# Altium schematic sheet Dual DC Motor Driver Rev.1.0.SchDoc
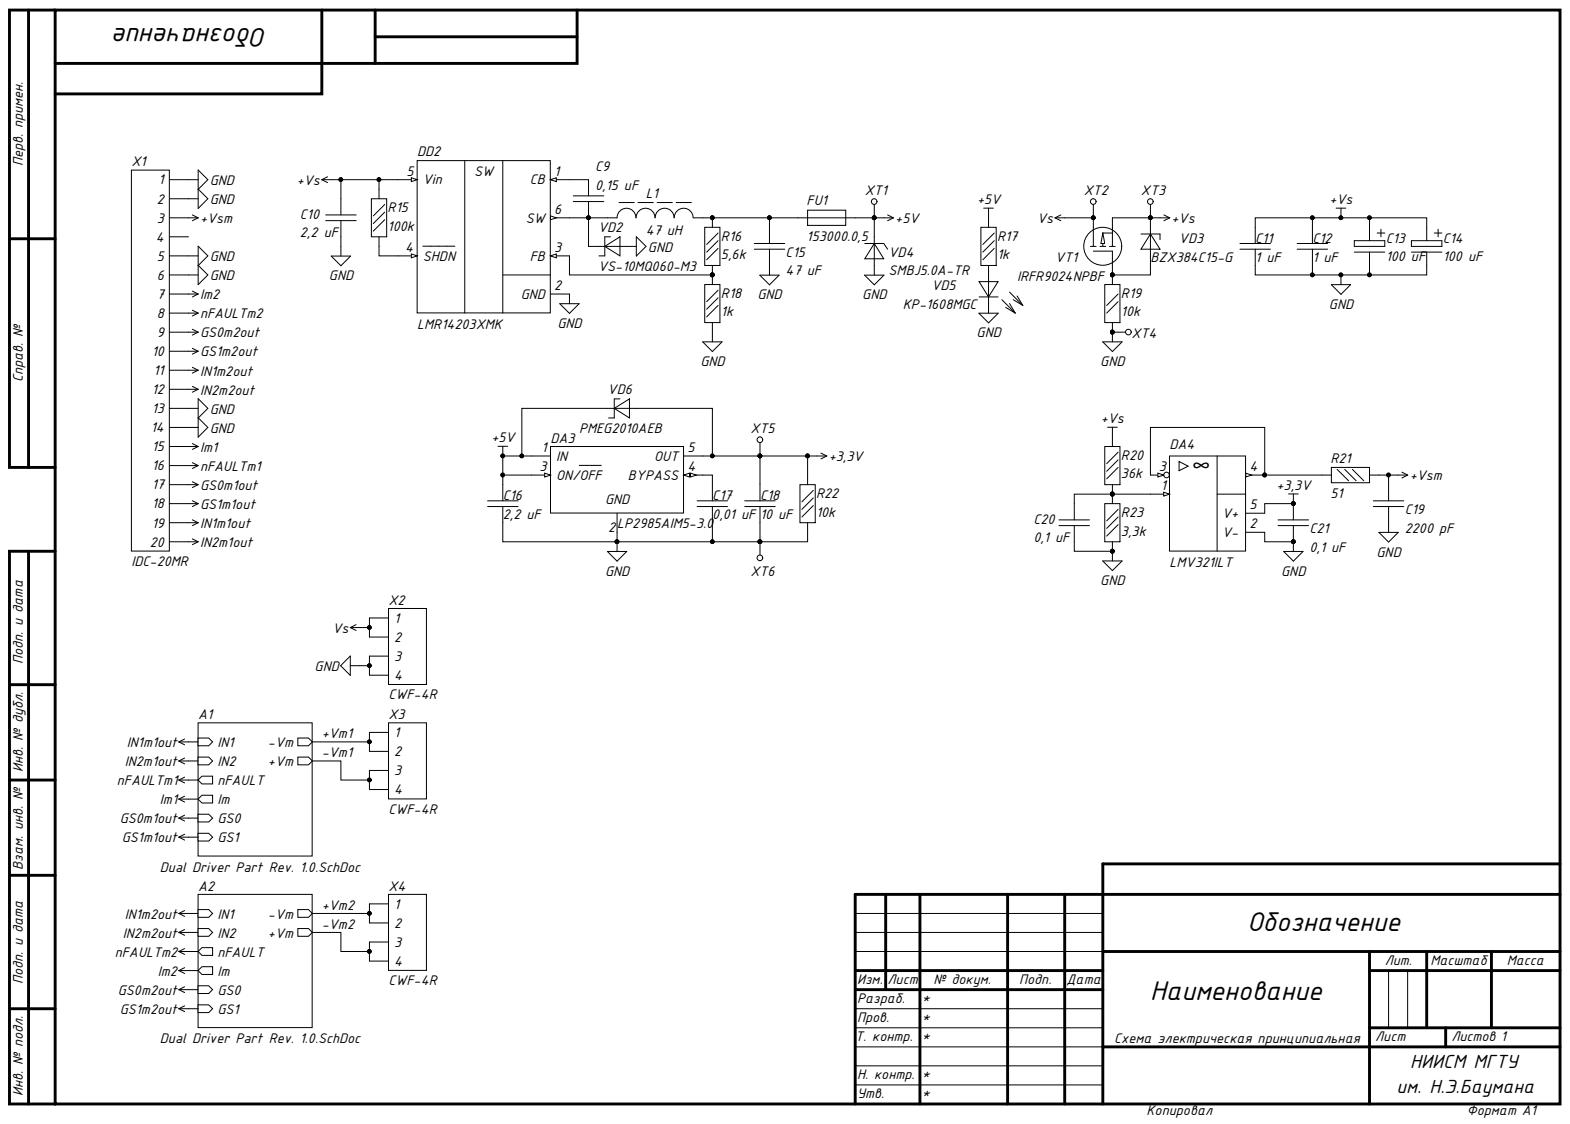
[diagram p0: Dual DC Motor Driver Rev.1.0.SchDoc]

## Parts
C9  Конденсатор  [CAPС_0603(1608)]  (value not drawn)
C10  Capacitance: 2,2 uF  [CAPС_1206(3216)]  (hidden comment: Конденсатор)
C11  Capacitance: 1 uF  [CAPС_1206(3216)]  (hidden comment: Конденсатор)
C12  Capacitance: 1 uF  [CAPС_1206(3216)]  (hidden comment: Конденсатор)
C13  EEUFC1J101  [D10L12.5d0.6F5.SLDPRT]  (value not drawn)
C14  Capacitance: 100 uF  [D10L12.5d0.6F5.SLDPRT]  (hidden comment: EEUFC1J101)
C15  Capacitance: 47 uF  [CAPС_1206(3216)]  (hidden comment: Конденсатор)
C16  Конденсатор  [CAPС_0603(1608)]  (value not drawn)
C17  Конденсатор  [CAPС_0603(1608)]  (value not drawn)
C18  Capacitance: 10 uF  [CAPС_0603(1608)]  (hidden comment: Конденсатор)
C19  Конденсатор точн.  [CAPС_0603(1608)]  (value not drawn)
C20  Capacitance: 0,1 uF  [CAPС_0603(1608)]  (hidden comment: Конденсатор)
C21  Capacitance: 0,1 uF  [CAPС_0603(1608)]  (hidden comment: Конденсатор)
DA3  LP2985AIM5-3.0  [SOT23-5]
DA4  LMV321ILT  [SOT23-5]
DD2  LMR14203XMK  [SOT23-6]
FU1  Предохранитель плавкий  [FUSC_0805(2012)]  (value not drawn)
L1  Inductance: 47 uH  [IND-6.5x6.5x4.6r0.8]  (hidden comment: SRR0604-470KL)
R15  Resistance: 100k  [RESC_0603(1608)]  (hidden comment: Резистор 0,05 Вт)
R16  Resistance: 5,6k  [RESC_0603(1608)]  (hidden comment: Резистор 0,05 Вт точн.)
R17  Resistance: 1k  [RESC_0603(1608)]  (hidden comment: Резистор 0,05 Вт)
R18  Resistance: 1k  [RESC_0603(1608)]  (hidden comment: Резистор 0,05 Вт точн.)
R19  Resistance: 10k  [RESC_0805(2012)]  (hidden comment: Резистор 0,125 Вт)
R20  Resistance: 36k  [RESC_0603(1608)]  (hidden comment: Резистор 0,05 Вт точн.)
R21  Резистор 0,05 Вт точн.  [RESC_0603(1608)]  (value not drawn)
R22  Resistance: 10k  [RESC_0603(1608)]  (hidden comment: Резистор 0,05 Вт)
R23  Resistance: 3,3k  [RESC_0603(1608)]  (hidden comment: Резистор 0,05 Вт точн.)
VD2  VS-10MQ060-M3  [DIODE_SMA]
VD3  BZX384C15-G  [SOD323]
VD4  SMBJ5.0A-TR  [DIODE_SMB]
VD5  KP-1608MGC  [DIODC_0603(1608)]
VD6  PMEG2010AEB  [SOD523]
VT1  IRFR9024NPBF  [TO-252-3(D-PAK)]
X1  IDC-20MR  [BH-20R (IDC-20MR)]
X2  CWF-4R  [CWF-4R]
X3  CWF-4R  [CWF-4R]
X4  CWF-4R  [CWF-4R]
XT1  Test point D1.0mm  [d1.0D1.8]  (value not drawn)
XT2  Test point D1.0mm  [d1.0D1.8]  (value not drawn)
XT3  Test point D1.0mm  [d1.0D1.8]  (value not drawn)
XT4  Test point D1.0mm  [d1.0D1.8]  (value not drawn)
XT5  Test point D1.0mm  [d1.0D1.8]  (value not drawn)
XT6  Test point D1.0mm  [d1.0D1.8]  (value not drawn)

## Nets
+3,3V: C18.2 C21.2 DA3.5(OUT) DA4.5(V+) R22.2 VD6.2 XT5.1
+5V: C16.2 DA3.1(IN) DA3.3(ON/OFF) FU1.2 R17.2 VD4.1 VD6.1 XT1.1
+Vs: C10.2 C11.2 C12.2 C13.1 C14.1 DD2.5(Vin) R15.2 R20.2 VD3.1 VT1.3 XT3.1
+Vsm: C19.2 R21.2 X1.3
GND: C10.1 C11.1 C12.1 C13.2 C14.2 C15.1 C16.1 C17.1 C18.1 C19.1 C20.1 C21.1 DA3.2 DA4.2(V-) DD2.2(GND) R18.1 R19.1 R22.1 R23.1 VD2.2 VD4.2 VD5.1 X1.1 X1.2 X1.5 X1.6 X1.13 X1.14 X2.3 X2.4 XT4.1 XT6.1
GS0m1out: X1.17
GS0m2out: X1.9
GS1m1out: X1.18
GS1m2out: X1.10
Im1: X1.15
Im2: X1.7
IN1m1out: X1.19
IN1m2out: X1.11
IN2m1out: X1.20
IN2m2out: X1.12
nFAULTm1: X1.16
nFAULTm2: X1.8
Vs: VT1.4 X2.1 X2.2 XT2.1
+Vm1: X3.1 X3.2
+Vm2: X4.1 X4.2
-Vm1: X3.3 X3.4
-Vm2: X4.3 X4.4
NetC9_1: C9.1 DD2.6(SW) L1.1 VD2.1
NetC9_2: C9.2 DD2.1(CB)
NetC15_2: C15.2 FU1.1 L1.2 R16.2
NetC17_2: C17.2 DA3.4(BYPASS)
NetC20_2: C20.2 DA4.1 R20.1 R23.2
NetDA4_3: DA4.3(∞) DA4.4 R21.1
NetDD2_3: DD2.3(FB) R16.1 R18.2
NetDD2_4: DD2.4(SHDN) R15.1
NetR17_1: R17.1 VD5.2
NetR19_2: R19.2 VD3.2 VT1.1
NetX1_4: X1.4

## Library data of the parts
C9 = Cap 603 0,15 uF: CAP 0,15 uF *V ±*% * 603; Capacitance: 0,15 uF; Database Table Name: C0603$; Library Рath: Foundation Library.SchLib; Category: Capacitors; Component Kind: Standard; ComponentLink1Description: Datasheet; Height: 0.035" (0.90mm); Library Name: DBLibrary.DbLib; Operating Temperature: -55°C ~ 125°C; Package / Case: 0603 (1608 Metric); Part Number: Cap 603 0,15 uF; Size / Dimension: 0.063" L x 0.031" W (1.60mm x 0.80mm); Supplier Device Package: 603; Tolerance: ±*%; Voltage - Rated: *V
C10 = Cap 1206 2,2 uF: CAP 2,2 uF 100V ±*% * 1206; Database Table Name: C1206$; Library Рath: Foundation Library.SchLib; Category: Capacitors; Component Kind: Standard; ComponentLink1Description: Datasheet; Library Name: DBLibrary.DbLib; Operating Temperature: -55°C ~ 125°C; Package / Case: 1206 (3216 Metric); Part Number: Cap 1206 2,2 uF; Supplier Device Package: 1206; Tolerance: ±*%; Voltage - Rated: 100V
C11 C12 = Cap 1206 1 uF: CAP 1 uF 100V ±*% * 1206; Database Table Name: C1206$; Library Рath: Foundation Library.SchLib; Category: Capacitors; Component Kind: Standard; ComponentLink1Description: Datasheet; Library Name: DBLibrary.DbLib; Operating Temperature: -55°C ~ 125°C; Package / Case: 1206 (3216 Metric); Part Number: Cap 1206 1 uF; Supplier Device Package: 1206; Tolerance: ±*%; Voltage - Rated: 100V
C13 = EEUFC1J101: Manufacturer: Panasonic Electronic Components; Capacitance: 100 uF; Capacitance tolerance: ±20%; Function: Aluminum Electrolytic Capacitors (Radial Lead Type); Rated voltage range: 63V; Analog: -; Category: Capacitors; Component Kind: Standard; ComponentLink1Description: Datasheet; ComponentLink1URL: https://industrial.panasonic.com/cdbs/www-data/pdf/RDF0000/ABA0000C1210.pdf; Description: EEUFC1J101; Library Name: Capacitors.SchLib; Manufacturer Part Number: EEUFC1J101; Mounting Type: Through-hole; Operating Temperature: -40°C to +105°C; Package / Case: D10L12.5d0.6F5; Part Number: EEUFC1J101; Specifications: -
C14 = EEUFC1J101: Manufacturer: Panasonic Electronic Components; Capacitance tolerance: ±20%; Function: Aluminum Electrolytic Capacitors (Radial Lead Type); Rated voltage range: 63V; Analog: -; Category: Capacitors; Component Kind: Standard; ComponentLink1Description: Datasheet; ComponentLink1URL: https://industrial.panasonic.com/cdbs/www-data/pdf/RDF0000/ABA0000C1210.pdf; Description: EEUFC1J101; Library Name: Capacitors.SchLib; Manufacturer Part Number: EEUFC1J101; Mounting Type: Through-hole; Operating Temperature: -40°C to +105°C; Package / Case: D10L12.5d0.6F5; Part Number: EEUFC1J101; Specifications: -
C15 = Cap 1206 47 uF: CAP 47 uF 16V ±*% * 1206; Database Table Name: C1206$; Library Рath: Foundation Library.SchLib; Category: Capacitors; Component Kind: Standard; ComponentLink1Description: Datasheet; Library Name: DBLibrary.DbLib; Operating Temperature: -55°C ~ 125°C; Package / Case: 1206 (3216 Metric); Part Number: Cap 1206 47 uF; Supplier Device Package: 1206; Tolerance: ±*%; Voltage - Rated: 16V
C16 = Cap 603 2,2 uF: CAP 2,2 uF 16V ±*% * 603; Capacitance: 2,2 uF; Database Table Name: C0603$; Library Рath: Foundation Library.SchLib; Category: Capacitors; Component Kind: Standard; ComponentLink1Description: Datasheet; Height: 0.035" (0.90mm); Library Name: DBLibrary.DbLib; Operating Temperature: -55°C ~ 125°C; Package / Case: 0603 (1608 Metric); Part Number: Cap 603 2,2 uF; Size / Dimension: 0.063" L x 0.031" W (1.60mm x 0.80mm); Supplier Device Package: 603; Tolerance: ±*%; Voltage - Rated: 16V
C17 = Cap 603 0,01 uF: CAP 0,01 uF *V ±*% * 603; Capacitance: 0,01 uF; Database Table Name: C0603$; Library Рath: Foundation Library.SchLib; Category: Capacitors; Component Kind: Standard; ComponentLink1Description: Datasheet; Height: 0.035" (0.90mm); Library Name: DBLibrary.DbLib; Operating Temperature: -55°C ~ 125°C; Package / Case: 0603 (1608 Metric); Part Number: Cap 603 0,01 uF; Size / Dimension: 0.063" L x 0.031" W (1.60mm x 0.80mm); Supplier Device Package: 603; Tolerance: ±*%; Voltage - Rated: *V
C18 = Cap 603 10 uF: CAP 10 uF 10V ±*% * 603; Database Table Name: C0603$; Library Рath: Foundation Library.SchLib; Category: Capacitors; Component Kind: Standard; ComponentLink1Description: Datasheet; Height: 0.035" (0.90mm); Library Name: DBLibrary.DbLib; Operating Temperature: -55°C ~ 125°C; Package / Case: 0603 (1608 Metric); Part Number: Cap 603 10 uF; Size / Dimension: 0.063" L x 0.031" W (1.60mm x 0.80mm); Supplier Device Package: 603; Tolerance: ±*%; Voltage - Rated: 10V
C19 = Cap 603 2200 pF NP0: CAP 2200 pF *V ±*% NP0 603; Capacitance: 2200 pF; Database Table Name: C0603 NP0$; Library Рath: Foundation Library.SchLib; Category: Capacitors; Component Kind: Standard; ComponentLink1Description: Datasheet; Dielectric: NP0; Height: 0.035" (0.90mm); Library Name: DBLibrary.DbLib; Operating Temperature: -55°C ~ 125°C; Package / Case: 0603 (1608 Metric); Part Number: Cap 603 2200 pF NP0; Size / Dimension: 0.063" L x 0.031" W (1.60mm x 0.80mm); Supplier Device Package: 603; Tolerance: ±*%; Voltage - Rated: *V
C20 C21 = Cap 603 0,1 uF: CAP 0,1 uF *V ±*% * 603; Database Table Name: C0603$; Library Рath: Foundation Library.SchLib; Category: Capacitors; Component Kind: Standard; ComponentLink1Description: Datasheet; Height: 0.035" (0.90mm); Library Name: DBLibrary.DbLib; Operating Temperature: -55°C ~ 125°C; Package / Case: 0603 (1608 Metric); Part Number: Cap 603 0,1 uF; Size / Dimension: 0.063" L x 0.031" W (1.60mm x 0.80mm); Supplier Device Package: 603; Tolerance: ±*%; Voltage - Rated: *V
DA3 = LP2985AIM5-3.0: Manufacturer: Texas Instruments; Manufacturer Part Number: LP2985AIM5-3.0/NOPB; Analog: -; Category: Linear Regulators (LDOs); Component Kind: Standard; ComponentLink1Description: Datasheet; ComponentLink1URL: http://www.ti.com/lit/ds/symlink/lp2985-n.pdf; Description: LP2985AIM5-3.0; Library Name: Misc Lib.SchLib; Package / Case: SOT-23-5; Part Number: LP2985AIM5-3.0/NOPB; Specifications: -
DA4 = LMV321ILT: Manufacturer: STMicroelectronics; Analog: -; Category: Amplifiers; Component Kind: Standard; ComponentLink1Description: Datasheet; ComponentLink1URL: https://www.st.com/resource/en/datasheet/lmv321.pdf; Description: LMV321ILT; Library Name: Misc Lib.SchLib; Manufacturer Part Number: LMV321ILT; Package / Case: SOT-23-5; Part Number: LMV321ILT; Specifications: -
DD2 = LMR14203XMK: Manufacturer: Texas Instruments; Analog: -; Category: DC/DC Switching Regulators; Component Kind: Standard; ComponentLink1Description: Datasheet; ComponentLink1URL: https://www.ti.com/lit/ds/symlink/lmr14203.pdf; Description: LMR14203XMK; Library Name: Misc Lib.SchLib; Manufacturer Part Number: LMR14203XMK; Package / Case: SOT-23-6; Part Number: LMR14203XMK; Specifications: -
FU1 = Fus 805 0,5A: FUS 0,5A 805 SMD; Manufacturer: SIBA; Manufacturer Part Number: 153000.0,5; Current: 0,5A; Database Table Name: FUSE0805$; Library Рath: Foundation Library.SchLib; Resistance: 570mOhm; Voltage Drop: 374mV; Category: Fuses; Component Kind: Standard; ComponentLink1Description: Datasheet; ComponentLink1URL: http://www.siba-fuses.com/upload/documents/catalogues/siba_gss_Katalog_Internet_12-10-15.pdf; Composition: Thick Film; Height: 0.024" (0.60mm); Library Name: DBLibrary.DbLib; Operating Temperature: -40°C ~ 85°C; Package / Case: 0805 (2012 Metric); Part Number: Fus 805 0,5A; Size / Dimension: 0.079" L x 0.049" W (2.00mm x 1.25mm); Supplier Device Package: 805
L1 = SRR0604-470KL: Manufacturer: Bourns Inc.; Function: SMT power inductors; Analog: -; Category: Inductors; Component Kind: Standard; ComponentLink1Description: Datasheet; ComponentLink1URL: https://bourns.com/docs/product-datasheets/srr0604.pdf; Description: SRR0604-470KL; Library Name: Inductors.SchLib; Manufacturer Part Number: SRR0604-470KL; Operating Temperature: -40°C ~ 125°C; Package / Case: IND-6.5x6.5x4.6r0.8; Part Number: SRR0604-470KL; Specifications: -
R15 = Res 603 100k ±5%: RES 100k OHM 0.1W ±5% 603 SMD; Database Table Name: R0603$; Library Рath: Foundation Library.SchLib; Temperature Coefficient: ±*ppm/°C; Category: Resistors; Component Kind: Standard; ComponentLink1Description: Datasheet; ComponentLink1URL: http://www.yageo.com/NewPortal/yageodocoutput?fileName=/pdf/R-Chip/PYu-RC_Group_51_RoHS_L_9.pdf; Height: 0.022" (0.55mm); Library Name: DBLibrary.DbLib; Operating Temperature: -55°C ~ 155°C; Package / Case: 0603 (1608 Metric); Part Number: Res 603 100k ±5%; Power (Watts): 0.1W; Size / Dimension: 0.063" L x 0.031" W (1.60mm x 0.80mm); Supplier Device Package: 603; Tolerance: ±5%
R16 = Res 603 5,6k ±1%: RES 5,6k OHM 0.1W ±1% 603 SMD; Database Table Name: R0603±1%$; Library Рath: Foundation Library.SchLib; Temperature Coefficient: ±*ppm/°C; Category: Resistors; Component Kind: Standard; ComponentLink1Description: Datasheet; ComponentLink1URL: http://www.yageo.com/NewPortal/yageodocoutput?fileName=/pdf/R-Chip/PYu-RC_Group_51_RoHS_L_9.pdf; Height: 0.022" (0.55mm); Library Name: DBLibrary.DbLib; Operating Temperature: -55°C ~ 155°C; Package / Case: 0603 (1608 Metric); Part Number: Res 603 5,6k ±1%; Power (Watts): 0.1W; Size / Dimension: 0.063" L x 0.031" W (1.60mm x 0.80mm); Supplier Device Package: 603; Tolerance: ±1%
R17 = Res 603 1k ±5%: RES 1k OHM 0.1W ±5% 603 SMD; Database Table Name: R0603$; Library Рath: Foundation Library.SchLib; Temperature Coefficient: ±*ppm/°C; Category: Resistors; Component Kind: Standard; ComponentLink1Description: Datasheet; ComponentLink1URL: http://www.yageo.com/NewPortal/yageodocoutput?fileName=/pdf/R-Chip/PYu-RC_Group_51_RoHS_L_9.pdf; Height: 0.022" (0.55mm); Library Name: DBLibrary.DbLib; Operating Temperature: -55°C ~ 155°C; Package / Case: 0603 (1608 Metric); Part Number: Res 603 1k ±5%; Power (Watts): 0.1W; Size / Dimension: 0.063" L x 0.031" W (1.60mm x 0.80mm); Supplier Device Package: 603; Tolerance: ±5%
R18 = Res 603 1k ±1%: RES 1k OHM 0.1W ±1% 603 SMD; Database Table Name: R0603±1%$; Library Рath: Foundation Library.SchLib; Temperature Coefficient: ±*ppm/°C; Category: Resistors; Component Kind: Standard; ComponentLink1Description: Datasheet; ComponentLink1URL: http://www.yageo.com/NewPortal/yageodocoutput?fileName=/pdf/R-Chip/PYu-RC_Group_51_RoHS_L_9.pdf; Height: 0.022" (0.55mm); Library Name: DBLibrary.DbLib; Operating Temperature: -55°C ~ 155°C; Package / Case: 0603 (1608 Metric); Part Number: Res 603 1k ±1%; Power (Watts): 0.1W; Size / Dimension: 0.063" L x 0.031" W (1.60mm x 0.80mm); Supplier Device Package: 603; Tolerance: ±1%
R19 = Res 805 10k ±5%: RES 10k OHM 0.125W ±5% 805 SMD; Database Table Name: R0805$; Library Рath: Foundation Library.SchLib; Temperature Coefficient: ±*ppm/°C; Category: Resistors; Component Kind: Standard; ComponentLink1Description: Datasheet; ComponentLink1URL: http://www.yageo.com/NewPortal/yageodocoutput?fileName=/pdf/R-Chip/PYu-RC_Group_51_RoHS_L_9.pdf; Height: 0.024" (0.60mm); Library Name: DBLibrary.DbLib; Operating Temperature: -55°C ~ 155°C; Package / Case: 0805 (2012 Metric); Part Number: Res 805 10k ±5%; Power (Watts): 0.125W; Size / Dimension: 0.079" L x 0.049" W (2.00mm x 1.25mm); Supplier Device Package: 805; Tolerance: ±5%
R20 = Res 603 36k ±1%: RES 36k OHM 0.1W ±1% 603 SMD; Database Table Name: R0603±1%$; Library Рath: Foundation Library.SchLib; Temperature Coefficient: ±*ppm/°C; Category: Resistors; Component Kind: Standard; ComponentLink1Description: Datasheet; ComponentLink1URL: http://www.yageo.com/NewPortal/yageodocoutput?fileName=/pdf/R-Chip/PYu-RC_Group_51_RoHS_L_9.pdf; Height: 0.022" (0.55mm); Library Name: DBLibrary.DbLib; Operating Temperature: -55°C ~ 155°C; Package / Case: 0603 (1608 Metric); Part Number: Res 603 36k ±1%; Power (Watts): 0.1W; Size / Dimension: 0.063" L x 0.031" W (1.60mm x 0.80mm); Supplier Device Package: 603; Tolerance: ±1%
R21 = Res 603 51 ±1%: RES 51 OHM 0.1W ±1% 603 SMD; Database Table Name: R0603±1%$; Library Рath: Foundation Library.SchLib; Resistance: 51; Temperature Coefficient: ±*ppm/°C; Category: Resistors; Component Kind: Standard; ComponentLink1Description: Datasheet; ComponentLink1URL: http://www.yageo.com/NewPortal/yageodocoutput?fileName=/pdf/R-Chip/PYu-RC_Group_51_RoHS_L_9.pdf; Height: 0.022" (0.55mm); Library Name: DBLibrary.DbLib; Operating Temperature: -55°C ~ 155°C; Package / Case: 0603 (1608 Metric); Part Number: Res 603 51 ±1%; Power (Watts): 0.1W; Size / Dimension: 0.063" L x 0.031" W (1.60mm x 0.80mm); Supplier Device Package: 603; Tolerance: ±1%
R22 = Res 603 10k ±5%: RES 10k OHM 0.1W ±5% 603 SMD; Database Table Name: R0603$; Library Рath: Foundation Library.SchLib; Temperature Coefficient: ±*ppm/°C; Category: Resistors; Component Kind: Standard; ComponentLink1Description: Datasheet; ComponentLink1URL: http://www.yageo.com/NewPortal/yageodocoutput?fileName=/pdf/R-Chip/PYu-RC_Group_51_RoHS_L_9.pdf; Height: 0.022" (0.55mm); Library Name: DBLibrary.DbLib; Operating Temperature: -55°C ~ 155°C; Package / Case: 0603 (1608 Metric); Part Number: Res 603 10k ±5%; Power (Watts): 0.1W; Size / Dimension: 0.063" L x 0.031" W (1.60mm x 0.80mm); Supplier Device Package: 603; Tolerance: ±5%
R23 = Res 603 3,3k ±1%: RES 3,3k OHM 0.1W ±1% 603 SMD; Database Table Name: R0603±1%$; Library Рath: Foundation Library.SchLib; Temperature Coefficient: ±*ppm/°C; Category: Resistors; Component Kind: Standard; ComponentLink1Description: Datasheet; ComponentLink1URL: http://www.yageo.com/NewPortal/yageodocoutput?fileName=/pdf/R-Chip/PYu-RC_Group_51_RoHS_L_9.pdf; Height: 0.022" (0.55mm); Library Name: DBLibrary.DbLib; Operating Temperature: -55°C ~ 155°C; Package / Case: 0603 (1608 Metric); Part Number: Res 603 3,3k ±1%; Power (Watts): 0.1W; Size / Dimension: 0.063" L x 0.031" W (1.60mm x 0.80mm); Supplier Device Package: 603; Tolerance: ±1%
VD2 = VS-10MQ060-M3: Manufacturer: Vishay Semiconductor Diodes Division; Manufacturer Device Package: DO-214AC (SMA); Function: Schottky Rectifier; Analog: -; Component Kind: Standard; ComponentLink1Description: Datasheet; ComponentLink1URL: http://www.vishay.com/docs/93351/vs-10mq060m.pdf; Description: VS-10MQ060-M3; Library Name: Diodes.SchLib; Manufacturer Part Number: VS-10MQ060-M3; Operating Temperature: -55°C ~ 150°C; Package / Case: DO-214AC, SMA; Part Number: VS-10MQ060-M3; Specifications: -
VD3 = BZX384C15-G: Manufacturer: Vishay Semiconductor Diodes Division; Manufacturer Device Package: SOD-323; Function: Small Signal Zener Diode; Analog: -; Component Kind: Standard; ComponentLink1Description: Datasheet; ComponentLink1URL: http://www.vishay.com/docs/83335/bzx384g.pdf; Description: BZX384C15-G; Library Name: Diodes.SchLib; Manufacturer Part Number: BZX384C15-G; Operating Temperature: -55°C ~ 150°C; Package / Case: SC-76, SOD-323; Part Number: BZX384C15-G; Specifications: -
VD4 = SMBJ5.0A-TR: Manufacturer: STMicroelectronics; Manufacturer Device Package: SMB (DO-214AA); Function: ESD\Surge Protection Unidirectional Diodes; Analog: -; Component Kind: Standard; ComponentLink1Description: Datasheet; ComponentLink1URL: https://www.st.com/resource/ja/datasheet/smbj.pdf; Description: SMBJ5.0A-TR; Library Name: Diodes.SchLib; Manufacturer Part Number: SMBJ5.0A-TR; Operating Temperature: -55°C ~ 150°C; Package / Case: DO-214AA, SMB; Part Number: SMBJ5.0A-TR; Specifications: -
VD5 = KP-1608MGC: Manufacturer: Kingbright; Manufacturer Device Package: 0603 (1608 Metric); Function: SMD Chip LED Lamp; Analog: -; Category: Diodes; Component Kind: Standard; ComponentLink1Description: Datasheet; ComponentLink1URL: http://www.farnell.com/datasheets/55766.pdf; Description: KP-1608MGC; Library Name: Diodes.SchLib; Manufacturer Part Number: KP-1608MGC; Operating Temperature: -40°C ~ 85°C; Package / Case: 0603 (1608 Metric); Part Number: KP-1608MGC; Specifications: -
VD6 = PMEG2010AEB: Manufacturer: Nexperia USA Inc.; Manufacturer Device Package: SOD-523; Forward current: 1A; Function: Schottky Rectifier; Reverse voltage: 20V; Analog: -; Component Kind: Standard; ComponentLink1Description: Datasheet; ComponentLink1URL: https://assets.nexperia.com/documents/data-sheet/PMEG2010AEB.pdf; Description: PMEG2010AEB; Library Name: Diodes.SchLib; Manufacturer Part Number: PMEG2010AEB; Operating Temperature: -65°C ~ 150°C; Package / Case: SC-79, SOD-523; Part Number: PMEG2010AEB; Specifications: -
VT1 = IRFR9024NPBF: Manufacturer: Infineon Technologies; Function: P-Channel Power MOSFET; Analog: -; Category: Transistors; Component Kind: Standard; ComponentLink1Description: Datasheet; ComponentLink1URL: https://www.infineon.com/dgdl/irfr9024npbf.pdf?fileId=5546d462533600a401535635f91d2132; Description: IRFR9024NPBF; Library Name: Transistors.SchLib; Manufacturer Part Number: IRFR9024NPBF; Operating Temperature: -55°C ~ 150°C; Package / Case: TO-252-3, DPak (2 Leads + Tab), SC-63; Part Number: IRFR9024NPBF; Specifications: -
X1 = IDC-20MR (BH-20R): Manufacturer: Connfly Electronic Co., Ltd.; Manufacturer Part Number: BH-20R (DS1013-20R) (IDC-20MR); Pitch: 2,54mm; Category: Connectors; Component Kind: Standard; Description: IDC-20MR (BH-20R); Library Name: Connectors.SchLib; Operating Temperature: -40°C ~ 105°C; Part Number: IDC-20MR (BH-20R)
X2-X4 = CWF-4R: CWF-4R (DS1069-4MRW6SA); Manufacturer: Connfly Electronic Co., Ltd.; Pitch: 2,5mm; Category: Connectors; Component Kind: Standard; Library Name: Connectors.SchLib; Manufacturer Part Number: CWF-4R (DS1069-4MRW6SA); Operating Temperature: -25°C ~ 85°C; Part Number: CWF-4R
XT1-XT6 = Test point D1.0mm: Test point, 1-Pin; Pitch: 2,54mm; Category: Connectors; Component Kind: Standard; Library Name: Connectors.SchLib; Part Number: Test point D1.0
